# Altium schematic sheet Conn.SchDoc(Conn)
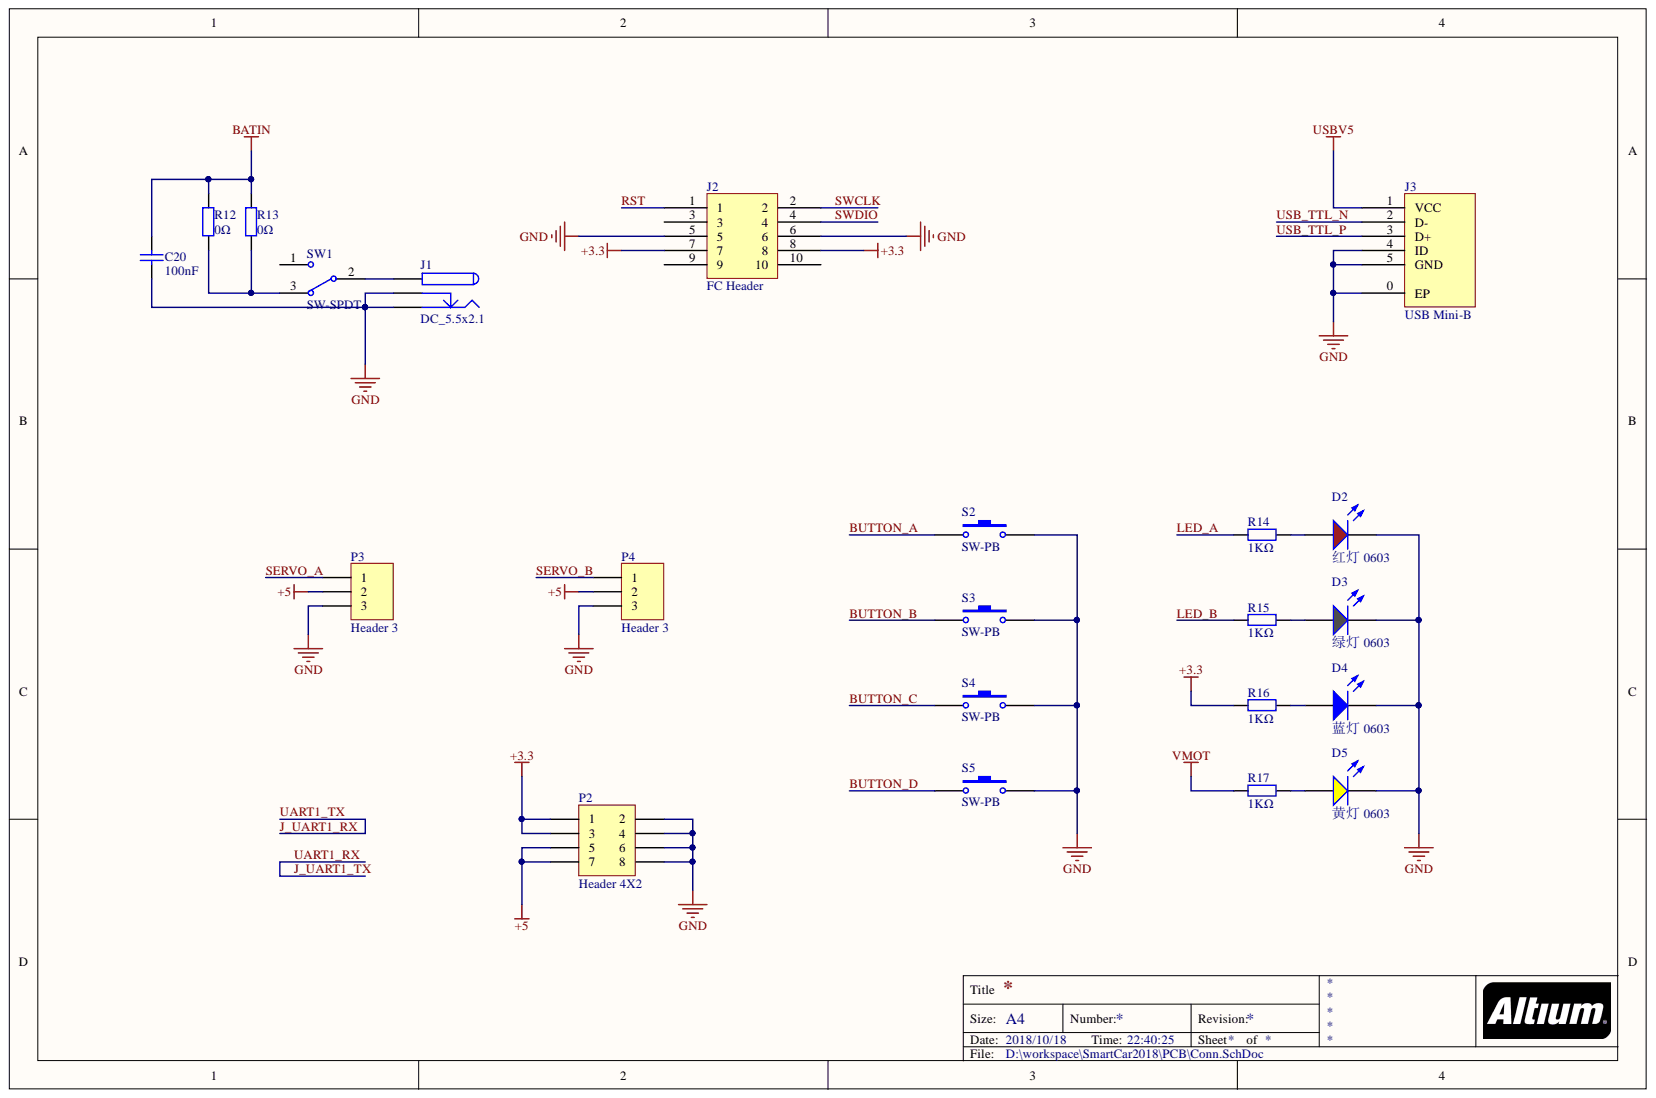
[diagram p0: Conn.SchDoc]

## Parts
C20  100nF  [0603_C]
D2  红灯 0603  Package: 0603  [0603_LED_S1]
D3  绿灯 0603  Package: 0603  [0603_LED_S1]
D4  蓝灯 0603  Package: 0603  [0603_LED_S1]
D5  黄灯 0603  Package: 0603  [0603_LED_S1]
J1  DC_5.5x2.1  [DC-005]
J2  FC Header  [FC-DC3-10P]
J3  USB Mini-B  [MINI-USB]
P2  Header 4X2  [HDR2X4]
P3  Header 3  [HDR1X3]
P4  Header 3  [HDR1X3]
R12  0Ω  [0805_R]
R13  0Ω  [0805_R]
R14  1KΩ  [0603_R]
R15  1KΩ  [0603_R]
R16  1KΩ  [0603_R]
R17  1KΩ  [0603_R]
S2  SW-PB  [SW_PB2_A1]
S3  SW-PB  [SW_PB2_A1]
S4  SW-PB  [SW_PB2_A1]
S5  SW-PB  [SW_PB2_A1]
SW1  SW-SPDT  [SWITCH_A2]

## Nets
+3.3: J2.7 J2.8 P2.1 P2.3 R16.1
+5: P2.5 P2.7 P3.2 P4.2
BATIN: C20.2 R12.2 R13.2
GND: C20.1 D2.K D3.K D4.K D5.K J1.2 J1.3 J2.5 J2.6 J3.0(EP) J3.4(ID) J3.5(GND) P2.2 P2.4 P2.6 P2.8 P3.3 P4.3 S2.2 S3.2 S4.2 S5.2
USBV5: J3.1(VCC)
VMOT: R17.1
BUTTON_A: S2.1
BUTTON_B: S3.1
BUTTON_C: S4.1
BUTTON_D: S5.1
LED_A: R14.1
LED_B: R15.1
RST: J2.1
SERVO_A: P3.1
SERVO_B: P4.1
SWCLK: J2.2
SWDIO: J2.4
USB_TTL_N: J3.2(D-)
USB_TTL_P: J3.3(D+)
NetD2_A: D2.A R14.2
NetD3_A: D3.A R15.2
NetD4_A: D4.A R16.2
NetD5_A: D5.A R17.2
NetJ1_4: J1.4 SW1.2
NetR12_1: R12.1 R13.1 SW1.3
J_UART1_RX:
J_UART1_TX:

Not connected: J2 3 9 10; SW1 1

## Library data of the parts
C20 = 0603 100nF (104) 10% 50V: 贴片电容; SuppliersPartNumber: C14663; Supplier: LC; Package: 0603; Library Name: Cap.SchLib; ComponentLink1URL: http://www.szlcsc.com/product/details_15331.html; ComponentLink1Description: 供应商链接; Component Kind: Standard
D2 = 0603 红灯: 发光二极管; SuppliersPartNumber: C2286; Supplier: LC; Library Name: LED.SchLib; ComponentLink1URL: http://www.szlcsc.com/product/details_2659.html; ComponentLink1Description: 供应商链接; Component Kind: Standard
D3 = 0603 绿灯: 发光二极管; SuppliersPartNumber: C72043; Supplier: LC; Library Name: LED.SchLib; ComponentLink1URL: http://www.szlcsc.com/product/details_73152.html; ComponentLink1Description: 供应商链接; Component Kind: Standard
D4 = 0603 蓝灯: 发光二极管; SuppliersPartNumber: C72041; Supplier: LC; Library Name: LED.SchLib; ComponentLink1URL: http://www.szlcsc.com/product/details_73150.html; ComponentLink1Description: 供应商链接; Component Kind: Standard
D5 = 0603 黄灯: 发光二极管; SuppliersPartNumber: C72038; Supplier: LC; Library Name: LED.SchLib; ComponentLink1URL: http://www.szlcsc.com/product/details_73147.html; ComponentLink1Description: 供应商链接; Component Kind: Standard
J2 = FC-2x5P: Library Name: SmartCar.SchLib; Component Kind: Standard
J3 = MINI_USB: Library Name: SmartCar.SchLib; Component Kind: Standard
P2 = Header 4X2: Header, 4-Pin, Dual row; Signal Integrity: Connector; Library Name: Miscellaneous Connectors.IntLib; LatestRevisionNote: Re-released for DXP Platform.; LatestRevisionDate: 17-Jul-2002; Component Kind: Standard; Publisher: Altium Limited
P3 P4 = Header 3: Header, 3-Pin; Signal Integrity: Connector; Library Name: Miscellaneous Connectors.IntLib; LatestRevisionNote: Re-released for DXP Platform.; LatestRevisionDate: 17-Jul-2002; Component Kind: Standard; Publisher: Altium Limited
R12 R13 = 0805 0Ω (0R0) 1%: 贴片电阻; SuppliersPartNumber: C17477; Supplier: LC; Package: 0805; Library Name: RES.SchLib; ComponentLink1URL: http://www.szlcsc.com/product/details_18165.html; ComponentLink1Description: 供应商链接; Component Kind: Standard
R14-R17 = 0603 1KΩ (1001) 1%: 贴片电阻; SuppliersPartNumber: C21190; Supplier: LC; Package: 0603; Library Name: RES.SchLib; ComponentLink1URL: http://www.szlcsc.com/product/details_21904.html; ComponentLink1Description: 供应商链接; Component Kind: Standard
S2-S5 = SW-PB: 微动开关; Published: 24-Mar-1999; PackageReference: SPST-2; LatestRevisionNote: Re-released for DXP Platform.; LatestRevisionDate: 17-Jul-2002; Component Kind: Standard; Publisher: Altium Limited; Library Name: 主集成库.IntLib
SW1 = SW-SPDT: 开关; Published: 24-Mar-1999; PackageReference: TL36WW15050; LatestRevisionNote: Schematic revised, PCB Footprint replaced.; LatestRevisionDate: 17-Dec-2003; DatasheetDocument: 05-Dec-2000; ComponentLink1URL: http://www.apem.com; ComponentLink1Description: Manufacturer Link; Component Kind: Standard; Publisher: Altium Limited; Library Name: 主集成库.IntLib
J1 = DC_5.5x2.1: SupplierPartNumber: C16214; Library Name: SmartCar.SchLib; Component Kind: Standard
